# Altium schematic sheet rs232_12V_step_Vadim.SchDoc
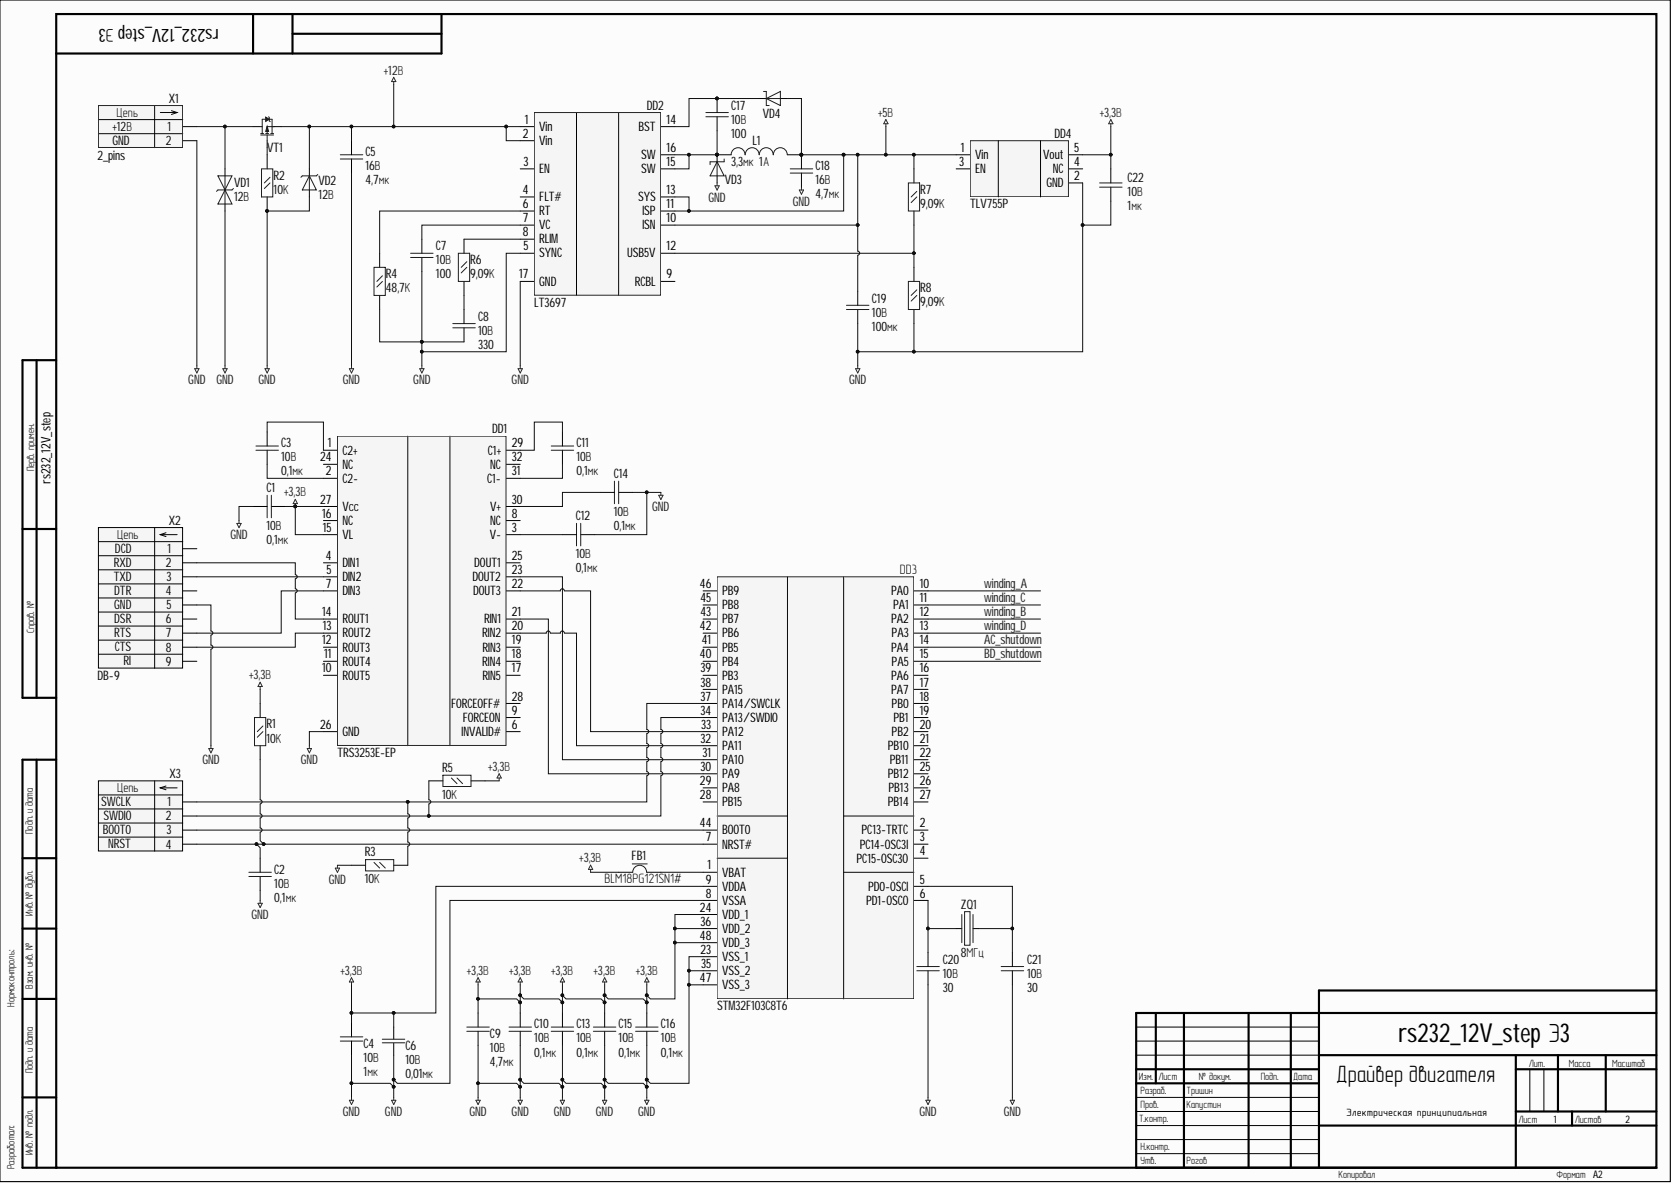
[diagram p0: rs232_12V_step_Vadim.SchDoc]

## Parts
C1  Capacitance: 0,1мк  Voltage: 10В  [CH0402C]  (hidden comment: Capacitor)
C2  Capacitance: 0,1мк  Voltage: 10В  [CH0402C]  (hidden comment: Capacitor)
C3  Capacitance: 0,1мк  Voltage: 10В  [CH0402C]  (hidden comment: Capacitor)
C4  Capacitance: 1мк  Voltage: 10В  [CH0201C]  (hidden comment: Capacitor)
C5  Capacitance: 4,7мк  Voltage: 16В  [CH0603C]  (hidden comment: Capacitor)
C6  Capacitance: 0,01мк  Voltage: 10В  [CH0402C]  (hidden comment: Capacitor)
C7  Voltage: 10В  [CH0201C]  (hidden comment: Capacitor)
C8  Voltage: 10В  [CH0402C]  (hidden comment: Capacitor)
C9  Capacitance: 4,7мк  Voltage: 10В  [CH0402C]  (hidden comment: Capacitor)
C10  Capacitance: 0,1мк  Voltage: 10В  [CH0402C]  (hidden comment: Capacitor)
C11  Capacitance: 0,1мк  Voltage: 10В  [CH0402C]  (hidden comment: Capacitor)
C12  Capacitance: 0,1мк  Voltage: 10В  [CH0402C]  (hidden comment: Capacitor)
C13  Capacitance: 0,1мк  Voltage: 10В  [CH0402C]  (hidden comment: Capacitor)
C14  Capacitance: 0,1мк  Voltage: 10В  [CH0402C]  (hidden comment: Capacitor)
C15  Capacitance: 0,1мк  Voltage: 10В  [CH0402C]  (hidden comment: Capacitor)
C16  Capacitance: 0,1мк  Voltage: 10В  [CH0402C]  (hidden comment: Capacitor)
C17  Voltage: 10В  [CH0201C]  (hidden comment: Capacitor)
C18  Capacitance: 4,7мк  Voltage: 16В  [CH0603C]  (hidden comment: Capacitor)
C19  Capacitance: 100мк  Voltage: 10В  [CH1206C]  (hidden comment: Capacitor)
C20  Voltage: 10В  [CH0201C]  (hidden comment: Capacitor)
C21  Voltage: 10В  [CH0201C]  (hidden comment: Capacitor)
C22  Capacitance: 1мк  Voltage: 10В  [CH0201C]  (hidden comment: Capacitor)
DD1  TRS3253E-EP  [TRS3253E-EP]
DD2  LT3697  [LT3697]
DD3  STM32F103C8T6  [STM32F103 48pins]
DD4  TLV755P  [SOT23-5]
FB1  Model: BLM18PG121SN1#  [CH0603L]  (hidden comment: Ferrite bead)
L1  Inductance: 3,3мк  Max current: 1А  [CH0805L]
R1  Impedance: 10К  [CH0805R]  (hidden comment: Res_0.125)
R2  Impedance: 10К  [CH0402R]  (hidden comment: Res_0.125)
R3  Impedance: 10К  [CH0805R]  (hidden comment: Res_0.125)
R4  Impedance: 48,7К  [CH0805R]  (hidden comment: Res_0.125)
R5  Impedance: 10К  [CH0805R]  (hidden comment: Res_0.125)
R6  Impedance: 9,09К  [CH0805R]  (hidden comment: Res_0.125)
R7  Impedance: 9,09К  [CH0805R]  (hidden comment: Res_0.125)
R8  Impedance: 9,09К  [CH0805R]  (hidden comment: Res_0.125)
VD1  Clamping Voltage: 12В  [CH0201L]  (hidden comment: TVS-diod_simmetrical)
VD2  Clamping_Voltage: 12В  [DO214AA]  (hidden comment: TVS-diod_directed)
VD3  Diod Schottky  [DO214AA]  (value not drawn)
VD4  Diod Schottky  [SOT23_132]  (value not drawn)
VT1  (no value)  [SOT23_132]
X1  2_pins  Vcc: +12В  [CON: 163-179PH-EX]
X2  DB-9  1: DCD  2: RXD  3: TXD  4: DTR  5: GND  6: DSR  7: RTS  8: CTS  9: RI  [CON: DB-9F]
X3  1: SWCLK  2: SWDIO  3: BOOT0  4: NRST  [CON: CWF-4R]  (hidden comment: 4_pins)
ZQ1  Freq: 8МГц  [OSC: 5032]  (hidden comment: Oscillator)

## Nets
+3,3В: C1.2 C4.2 C6.1 C9.2 C10.1 C13.1 C15.1 C16.1 C22.1 DD1.15(VL) DD1.27(Vcc) DD3.9(VDDA) DD3.24(VDD_1) DD3.36(VDD_2) DD3.48(VDD_3) DD4.5(Vout) FB1.1 R1.1 R5.2
+5В: C18.1 C19.1 DD2.10(ISN) DD2.11(ISP) DD2.13(SYS) DD4.1(Vin) L1.2 R7.1 VD4.1
+12В: C5.1 DD2.1(Vin) DD2.2(Vin) VD2.2 VT1.3
GND: C1.1 C2.2 C4.1 C5.2 C6.2 C7.2 C8.2 C9.1 C10.2 C12.2 C13.2 C14.2 C15.2 C16.2 C18.2 C19.2 C20.2 C21.2 C22.2 DD1.26(GND) DD2.5(SYNC) DD2.17(GND) DD3.8(VSSA) DD3.23(VSS_1) DD3.35(VSS_2) DD3.47(VSS_3) DD4.2(GND) R2.1 R3.1 R4.2 R8.2 VD1.1 VD2.1 VD3.1 X1.2 X2.5
AC_shutdown: DD3.14(PA4)
BD_shutdown: DD3.15(PA5)
winding_A: DD3.10(PA0)
winding_B: DD3.12(PA2)
winding_C: DD3.11(PA1)
winding_D: DD3.13(PA3)
NetC2_1: C2.1 DD3.7(NRST#) R1.2 X3.4
NetC3_1: C3.1 DD1.1(C2+)
NetC3_2: C3.2 DD1.2(C2-)
NetC7_1: C7.1 DD2.7(VC)
NetC8_1: C8.1 R6.2
NetC11_1: C11.1 DD1.29(C1+)
NetC11_2: C11.2 DD1.31(C1-)
NetC12_1: C12.1 DD1.3(V-)
NetC14_1: C14.1 DD1.30(V+)
NetC17_1: C17.1 DD2.14(BST) VD4.2
NetC17_2: C17.2 DD2.15(SW) DD2.16(SW) L1.1 VD3.2
NetC20_1: C20.1 DD3.6(PD1-OSCO) ZQ1.1
NetC21_1: C21.1 DD3.5(PD0-OSCI) ZQ1.3
NetDD1_5: DD1.5(DIN2) X2.3
NetDD1_7: DD1.7(DIN3) X2.7
NetDD1_13: DD1.13(ROUT2) X2.8
NetDD1_14: DD1.14(ROUT1) X2.2
NetDD1_20: DD1.20(RIN2) DD3.32(PA11)
NetDD1_21: DD1.21(RIN1) DD3.30(PA9)
NetDD1_22: DD1.22(DOUT3) DD3.33(PA12)
NetDD1_23: DD1.23(DOUT2) DD3.31(PA10)
NetDD2_6: DD2.6(RT) R4.1
NetDD2_8: DD2.8(RLIM) R6.1
NetDD2_12: DD2.12(USB5V) R7.2 R8.1
NetDD3_1: DD3.1(VBAT) FB1.2
NetDD3_34: DD3.34(PA13/SWDIO) R5.1 X3.2
NetDD3_37: DD3.37(PA14/SWCLK) R3.2 X3.1
NetDD3_44: DD3.44(BOOT0) X3.3
NetR2_2: R2.2 VT1.1
NetVD1_2: VD1.2 VT1.2 X1.1

Not connected: DD1 4(DIN1) 6(INVALID#) 8(NC) 9(FORCEON) 10(ROUT5) 11(ROUT4) 12(ROUT3) 16(NC) 17(RIN5) 18(RIN4) 19(RIN3) 24(NC) 25(DOUT1) 28(FORCEOFF#) 32(NC); DD2 3(EN) 4(FLT#) 9(RCBL); DD3 2(PC13-TRTC) 3(PC14-OSC3I) 4(PC15-OSC3O) 16(PA6) 17(PA7) 18(PB0) 19(PB1) 20(PB2) 21(PB10) 22(PB11) 25(PB12) 26(PB13) 27(PB14) 28(PB15) 29(PA8) 38(PA15) 39(PB3) 40(PB4) 41(PB5) 42(PB6) 43(PB7) 45(PB8) 46(PB9); DD4 3(EN) 4(NC); X2 1 4 6 9

## Library data of the parts
C1-C3 C10-C16 = Capacitor: Manufacture: AVX; Model: 0402ZD103KAT2A; Case: 0402; Component Kind: Standard; HelpURL: https://ru.mouser.com/ProductDetail/AVX/0402ZD103KAT2A?qs=sGAEpiMZZMs0AnBnWHyRQKdiqyDPVQdAu74W%2fwwRq8A%3d; Library Name: GOST_Capacitors.SchLib
C4 C22 = Capacitor: Manufacture: Murata Electronics; Model: GRM033C81A105ME05E; Case: 0201; Component Kind: Standard; HelpURL: https://ru.mouser.com/ProductDetail/Murata-Electronics/GRM033C81A105ME05E?qs=sGAEpiMZZMs0AnBnWHyRQN7%2fAA2D2lPPXfhIkJ3ChWM%252bNp28BKy3Ug%3d%3d; Library Name: GOST_Capacitors.SchLib
C5 C18 = Capacitor: Manufacture: Murata Electronics; Model: GRM188R61C475KAAJD; Case: 0603; Component Kind: Standard; HelpURL: https://ru.mouser.com/ProductDetail/Murata-Electronics/GRM188R61C475KAAJD?qs=sGAEpiMZZMs0AnBnWHyRQHS%2f3XE0oMwxE3tFP4oDIiY%3d; Library Name: GOST_Capacitors.SchLib
C6 = Capacitor: Manufacture: Yageo; Model: CC0402MRX7R6BB103; Case: 0402; Component Kind: Standard; HelpURL: https://ru.mouser.com/ProductDetail/Yageo/CC0402MRX7R6BB103?qs=sGAEpiMZZMs0AnBnWHyRQCF1lTWZ1EBLC11KxQK9NtY%3D; Library Name: GOST_Capacitors.SchLib
C7 C17 = Capacitor: Manufacture: KEMET; Capacitance: 100; Model: C0201C101K8GACTU; Case: 0201; Component Kind: Standard; HelpURL: https://ru.mouser.com/ProductDetail/KEMET/C0201C101K8GACTU?qs=sGAEpiMZZMs0AnBnWHyRQB5KqWiNAtmEn9gsTWOdKS%252b7RrFbxqtALA%3d%3d; Library Name: GOST_Capacitors.SchLib
C8 = Capacitor: Manufacture: Vishay / Vitramon; Capacitance: 330; Model: VJ0402Y331MXQCW1BC; Case: 0402; Component Kind: Standard; HelpURL: https://ru.mouser.com/ProductDetail/Vishay-Vitramon/VJ0402Y331MXQCW1BC?qs=sGAEpiMZZMs0AnBnWHyRQHefeAIkSpb%2fKjIwGvKZvs8%3d; Library Name: GOST_Capacitors.SchLib
C9 = Capacitor: Manufacture: Murata Electronics; Model: ZRB15XR61A475KE01D; Case: 0402; Component Kind: Standard; HelpURL: https://ru.mouser.com/ProductDetail/Murata-Electronics/ZRB15XR61A475KE01D?qs=sGAEpiMZZMs0AnBnWHyRQO9VYadaKTO%252beZnE9zFUsbv4FmCibz4ZfQ%3d%3d; Library Name: GOST_Capacitors.SchLib
C19 = Capacitor: Manufacture: TDK; Model: C3216X5R1A107M160AC; Case: 1206; Component Kind: Standard; HelpURL: https://ru.mouser.com/ProductDetail/TDK/C3216X5R1A107M160AC?qs=sGAEpiMZZMs0AnBnWHyRQEWjzA2rN6H9eWVfGykJv7Q%3d; Library Name: GOST_Capacitors.SchLib
C20 C21 = Capacitor: Manufacture: KEMET; Capacitance: 30; Model: CBR02C308B8GAC; Case: 0201; Component Kind: Standard; HelpURL: https://ru.mouser.com/ProductDetail/KEMET/CBR02C308B8GAC?qs=sGAEpiMZZMs0AnBnWHyRQKJCfzG%2fi9wHnix1yOwfSPhOUzhoHh%252bTpQ%3d%3d; Library Name: GOST_Capacitors.SchLib
DD1 = TRS3253E-EP: UART - RS232; Manufacture: Texas Instruments; Case: PQFN-32; Component Kind: Standard; HelpURL: http://www.ti.com/product/trs3253e-ep; Model: TRS3253E-EP; Library Name: GOST_lib_devices.SchLib
DD2 = LT3697: 6~18 to 5; Manufacture: Analog Devices; Component Kind: Standard; HelpURL: https://www.analog.com/en/products/lt3697.html; Model: LT3697; Library Name: GOST_lib_devices.SchLib
DD3 = STM32: Manufacture: STMicroelectronics; Case: LQFP48; Component Kind: Standard; HelpURL: https://www.st.com/en/microcontrollers-microprocessors/stm32f103c8.html; Model: STM32F103C8T6; Library Name: GOST_lib_devices.SchLib
DD4 = LDO +3.3V: Manufacture: Texas Instruments; Case: SOT-23; Component Kind: Standard; HelpUrL: http://www.ti.com/product/TLV755P?keyMatch=TLV755P&tisearch=Search-EN-Everything; Model: TLV755P; Library Name: GOST_lib_devices.SchLib
FB1 = Ferrite bead: Manufacture: murata; Impedance: 120; Max current: 2A; Case: 0603; Component Kind: Standard; HelpURL: https://www.murata.com/en-us/products/productdetail?partno=BLM18PG121SN1%23; Library Name: GOST_Inductors.SchLib
L1 = Inductor: Manufacture: Murata Electronics; Impedance: 150м; Model: LQM21PZ3R3MGRD; Case: 0805; Component Kind: Standard; HelpURL: https://ru.mouser.com/ProductDetail/Murata-Electronics/LQM21PZ3R3MGRD?qs=sGAEpiMZZMsg%252by3WlYCkU2iuTbKTbm6Zxs6Ix4GkUPA%3d; Library Name: GOST_Inductors.SchLib
R1 R3 R5-R8 = Res_0.125: Manufacture: Panasonic Industrial Devices; Model: ERJ-6ENF9091V; Case: 0805; Component Kind: Standard; HelpURL: https://ru.mouser.com/ProductDetail/Panasonic-Industrial-Devices/ERJ-6ENF9091V?qs=sGAEpiMZZMvdGkrng054tx7%2f6%252bNA3LAJZkxjwUnWjWM%3d; Library Name: GOST_Resistors.SchLib
R2 = Res_0.125: Manufacture: Yageo; Model: AC0402FR-7W10KL; Case: 0402; Component Kind: Standard; HelpURL: https://ru.mouser.com/ProductDetail/Yageo/AC0402FR-7W10KL?qs=sGAEpiMZZMvdGkrng054txbN%2f5LBcxld3gyqgmBuiXRPLjwmdNqO3A%3d%3d; Library Name: GOST_Resistors.SchLib
R4 = Res_0.125: Manufacture: Panasonic Industrial Devices; Model: ERJ-6ENF4872V; Case: 0805; Component Kind: Standard; HelpURL: https://ru.mouser.com/ProductDetail/Panasonic-Industrial-Devices/ERJ-6ENF4872V?qs=sGAEpiMZZMvdGkrng054tx7%2f6%252bNA3LAJLLBsBMFOrm0%3d; Library Name: GOST_Resistors.SchLib
VD1 = TVS-diod_simmetrical: Manufacturer: Littelfuse; Model: SP3022-01WTG; Case: 0201; Component Kind: Standard; HelpURL: https://ru.mouser.com/ProductDetail/Littelfuse/SP3022-01WTG?qs=sGAEpiMZZMsItbzKdwyIez7NGmqnzL8h47KYC2e%252bM%2f4NVETz1A7KGA%3d%3d; Library Name: GOST_Diodes.SchLib
VD2 = TVS-diod_directed: Manufacturer: Bourns; Model: CD214B-T7.0ALF; Case: DO-214AA-2; Component Kind: Standard; HelpURL: https://ru.mouser.com/ProductDetail/Bourns/CD214B-T70ALF?qs=sGAEpiMZZMsItbzKdwyIexL102H4jKkFOiaKL2EcEgo%3d; Library Name: GOST_Diodes.SchLib
VD3 = Diod Schottky: Manufacturer: Rectron; If: 3А; Model: FM5822B-W; Vrrm: 40В; Component Kind: Standard; HelpURL: https://ru.mouser.com/ProductDetail/Rectron/FM5822B-W?qs=sGAEpiMZZMtQ8nqTKtFS%2fGuc2LbLh1yNV0sywe9%2fy3k%3d; Library Name: GOST_Diodes.SchLib
VD4 = Diod Schottky: Manufacturer: Toshiba; If: 200мА; Model: 1SS374(TE85L,F); Vrrm: 15В; Component Kind: Standard; HelpURL: https://ru.mouser.com/ProductDetail/Toshiba/1SS374TE85LF?qs=sGAEpiMZZMtQ8nqTKtFS%2fOl9H8JU2QXlyYvmz0vcRmull8rlMQPxpQ%3d%3d; Library Name: GOST_Diodes.SchLib
VT1 = P-Channel: Manufacture: Diodes Incorporated; Id: 2,4А; Model: DMG2301LK-7; Vgs: 300мВ; Vsd: 20В; Component Kind: Standard; HelpURL: https://ru.mouser.com/ProductDetail/Diodes-Incorporated/DMG2301LK-7?qs=sGAEpiMZZMshyDBzk1%2fWi3VK%2fMVeCy7TGgocA8mg7FfG8rajAhy0Kw%3d%3d; Library Name: GOST_Transistors.SchLib
X2 = 9_pins: Case: DB-9; Component Kind: Standard; HelpURL: https://www.digikey.com/product-detail/en/assmann-wsw-components/A-DS-09-LL-Z/AE10972-ND/1241781; Model: DB-9; Library Name: GOST_lib_connectors.SchLib
X3 = 4_pins: Manufacture: Omron Electronics; Model: XN2D-4471; Component Kind: Standard; HelpURL: https://ru.mouser.com/ProductDetail/Omron-Electronics/XN2D-4471?qs=sGAEpiMZZMvd2mIcYG7oaC0TeQEBnk0JvHtcE4UuDS0%3D; Library Name: GOST_lib_connectors.SchLib
ZQ1 = Oscillator: Manufacture: CTS Electronic Components; Model: 636L3C008M00000; Case: 5x3,2mm; Component Kind: Standard; HelpURL: https://ru.mouser.com/ProductDetail/CTS-Electronic-Components/636L3C008M00000?qs=sGAEpiMZZMt8zWNA7msRCjl4WCD9T6KEFNudNQm%252BeAU%3D; Library Name: GOST_Miscelanuious.SchLib
X1 = 2_pins: Component Kind: Standard; HelpURL: https://ru.farnell.com/gct-global-connector-technology/dcj250-10-a-k1-k/dc-power-jack-5a-20vdc-black/dp/2524130?st=power%20jack; Library Name: GOST_lib_connectors.SchLib
